# Altium schematic sheet Sheet1.SchDoc
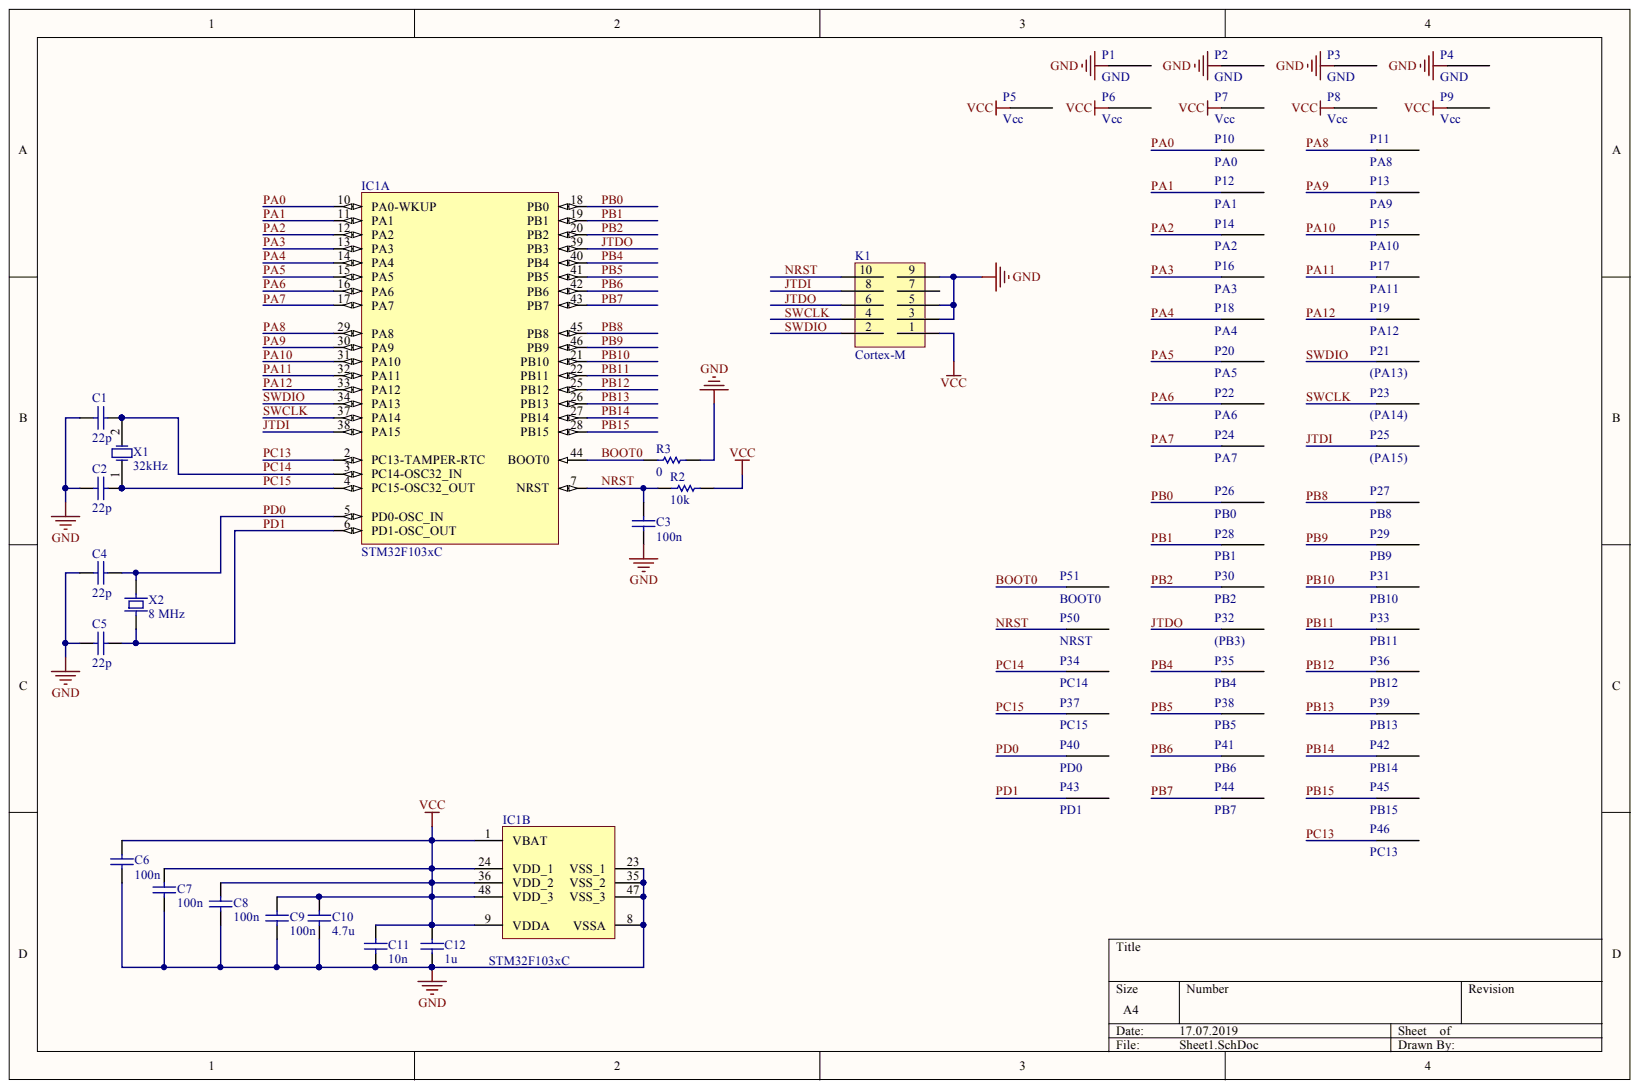
[diagram p0: Sheet1.SchDoc]

## Parts
C1  22p  [CAPC2013X88X50NL20T25]
C2  22p  [CAPC2013X88X50NL20T25]
C3  100n  [CAPC2013X88X50NL20T25]
C4  22p  [CAPC2013X88X50NL20T25]
C5  22p  [CAPC2013X88X50NL20T25]
C6  100n  [CAPC2013X88X50NL20T25]
C7  100n  [CAPC2013X88X50NL20T25]
C8  100n  [CAPC2013X88X50NL20T25]
C9  100n  [CAPC2013X88X50NL20T25]
C10  4.7u  [CAPC2013X88X50NL20T25]
C11  10n  [CAPC2013X88X50NL20T25]
C12  1u  [CAPC2013X88X50NL20T25]
IC1  STM32F103xC  [STM-LQFP48_N]  (parts A,B)
K1  Cortex-M  [PCB-l6fbhhbmmdzifrvjozvs-1]
P1  GND  [PIN-089]
P2  GND  [PIN-089]
P3  GND  [PIN-089]
P4  GND  [PIN-089]
P5  Vcc  [PIN-089]
P6  Vcc  [PIN-089]
P7  Vcc  [PIN-089]
P8  Vcc  [PIN-089]
P9  Vcc  [PIN-089]
P10  PA0  [PIN-089]
P11  PA8  [PIN-089]
P12  PA1  [PIN-089]
P13  PA9  [PIN-089]
P14  PA2  [PIN-089]
P15  PA10  [PIN-089]
P16  PA3  [PIN-089]
P17  PA11  [PIN-089]
P18  PA4  [PIN-089]
P19  PA12  [PIN-089]
P20  PA5  [PIN-089]
P21  (PA13)  [PIN-089]
P22  PA6  [PIN-089]
P23  (PA14)  [PIN-089]
P24  PA7  [PIN-089]
P25  (PA15)  [PIN-089]
P26  PB0  [PIN-089]
P27  PB8  [PIN-089]
P28  PB1  [PIN-089]
P29  PB9  [PIN-089]
P30  PB2  [PIN-089]
P31  PB10  [PIN-089]
P32  (PB3)  [PIN-089]
P33  PB11  [PIN-089]
P34  PC14  [PIN-089]
P35  PB4  [PIN-089]
P36  PB12  [PIN-089]
P37  PC15  [PIN-089]
P38  PB5  [PIN-089]
P39  PB13  [PIN-089]
P40  PD0  [PIN-089]
P41  PB6  [PIN-089]
P42  PB14  [PIN-089]
P43  PD1  [PIN-089]
P44  PB7  [PIN-089]
P45  PB15  [PIN-089]
P46  PC13  [PIN-089]
P50  NRST  [PIN-089]
P51  BOOT0  [PIN-089]
R2  10k  [RESC2012X07N]
R3  0  [RESC2012X07N]
X1  32kHz  [PCB-9zwnom3j82v50zoz89x1-1]
X2  8 MHz  [9B-8.000MEEJ-B]

## Nets
GND: C1.1 C2.1 C3.2 C4.1 C5.1 C6.2 C7.2 C8.2 C9.2 C10.2 C11.2 C12.2 IC1.8(VSSA) IC1.23(VSS_1) IC1.35(VSS_2) IC1.47(VSS_3) K1.3 K1.5 K1.9 P1.1 P2.1 P3.1 P4.1 R3.1
VCC: C6.1 C7.1 C8.1 C9.1 C10.1 C11.1 C12.1 IC1.1(VBAT) IC1.9(VDDA) IC1.24(VDD_1) IC1.36(VDD_2) IC1.48(VDD_3) K1.1 P5.1 P6.1 P7.1 P8.1 P9.1 R2.1
BOOT0: IC1.44(BOOT0) P51.1 R3.2
JTDI: IC1.38(PA15) K1.8 P25.1
JTDO: IC1.39(PB3) K1.6 P32.1
NRST: C3.1 IC1.7(NRST) K1.10 P50.1 R2.2
PA0: IC1.10(PA0-WKUP) P10.1
PA1: IC1.11(PA1) P12.1
PA2: IC1.12(PA2) P14.1
PA3: IC1.13(PA3) P16.1
PA4: IC1.14(PA4) P18.1
PA5: IC1.15(PA5) P20.1
PA6: IC1.16(PA6) P22.1
PA7: IC1.17(PA7) P24.1
PA8: IC1.29(PA8) P11.1
PA9: IC1.30(PA9) P13.1
PA10: IC1.31(PA10) P15.1
PA11: IC1.32(PA11) P17.1
PA12: IC1.33(PA12) P19.1
PB0: IC1.18(PB0) P26.1
PB1: IC1.19(PB1) P28.1
PB2: IC1.20(PB2) P30.1
PB4: IC1.40(PB4) P35.1
PB5: IC1.41(PB5) P38.1
PB6: IC1.42(PB6) P41.1
PB7: IC1.43(PB7) P44.1
PB8: IC1.45(PB8) P27.1
PB9: IC1.46(PB9) P29.1
PB10: IC1.21(PB10) P31.1
PB11: IC1.22(PB11) P33.1
PB12: IC1.25(PB12) P36.1
PB13: IC1.26(PB13) P39.1
PB14: IC1.27(PB14) P42.1
PB15: IC1.28(PB15) P45.1
PC13: IC1.2(PC13-TAMPER-RTC) P46.1
PC14: C1.2 IC1.3(PC14-OSC32_IN) P34.1 X1.2
PC15: C2.2 IC1.4(PC15-OSC32_OUT) P37.1 X1.1
PD0: C4.2 IC1.5(PD0-OSC_IN) P40.1 X2.2
PD1: C5.2 IC1.6(PD1-OSC_OUT) P43.1 X2.1
SWCLK: IC1.37(PA14) K1.4 P23.1
SWDIO: IC1.34(PA13) K1.2 P21.1

Not connected: K1 7

## Library data of the parts
C1-C12 = CMP-3913301-2: CAPACITOR, 0805, C0G, 50V, 2.2NF; Capacitance: 2.2e-09 F; Capacitance Tolerance: ±5%; Dielectric Characteristic: C0G/NP0; Dielectric Material: Ceramic; Lead-Free Status: Lead Free; Voltage Rating (DC): 50.0 V; Case/Package: 0805; Component Kind: Standard; Library Name: CommunityVault; Mounting Style: Surface Mount; OctopartID: bb4973a362c9648e; Octopart Url: https://octopart.com/c0805c222j5gacauto-kemet-31522022; Packaging: Cut Tape (CT); REACH SVHC Compliance: No SVHC; RoHS: Compliant
IC1 = STM32F103C8T6TR: ARM Cortex-M3 32-bit MCU, 64 KB Flash, 20 KB Internal RAM, 37 I/Os, 48-pin LQFP, -40 to 85 degC, Tape and Reel; Lead-Free Status: Lead Free; Component Kind: Standard; Library Name: Octopart library; Lifecycle Status: Active; OctopartID: c3c10a7d8bb51c06; Octopart Url: https://octopart.com/stm32f103c8t6tr-stmicroelectronics-11955474; RoHS: Compliant
K1 = WSL10-4: Wannensteckleiste 10 pin; CustomComponentID: 4svy7yiuz1vlprmntkh4; Component Kind: Standard; Library Name: FavoritesLibrary
P1-P46 P50 P51 = PIN-7: One-pin connector; CustomComponentID: iv9w4hav5xulhqaz2xo1; Component Kind: Standard; Library Name: FavoritesLibrary
R2 R3 = CMP-1041dbfef0d0cf86-1: Res, Thin Film, 7k87, 0.1%, 0.25w, 0805; Manufacturer Part Number: RP73PF2A7K87BTDF; Power Rating: 250 mW; Resistance: 7.87 kΩ; Voltage Rating (DC): 150 V; Case/Package: 0805, 2012; Component Kind: Standard; Library Name: CommunityVault; Lifecycle Status: Not Listed by Manufacturer; Mounting Style: Surface Mount; OctopartID: 1041dbfef0d0cf86; Octopart URL: http://octopart.com/rp73pf2a7k87btdf-te+connectivity-45467127; Packaging: Cut Tape (CT); REACH SVHC Compliance: No SVHC; Resistance Tolerance: ±0.1%; RoHS: Compliant
X1 = AB38T-32.768KHZ: AB38T Series 32.768 kHz ±20 ppm 12.5 pF -10 to +60 °C Tuning Fork Crystal; Equivalent Series Resistance (ESR): 30.0 kΩ; Frequency: 32.8 kHz; Lead-Free Status: Lead Free; Load Capacitance: 12.5 pF; Component Kind: Standard; Library Name: Octopart library; Mounting Style: Through Hole; Number of Pins: 2; OctopartID: 1ccad5c4e9f7c30d; Octopart URL: http://octopart.com/ab38t-32.768khz-abracon-315483; Packaging: Bulk; REACH SVHC Compliance: No SVHC; Size-Height: 8.30 mm
X2 = 9B-8.000MEEJ-B: Crystal 8MHz +/-10ppm (Tol) +/-20ppm (Stability) 18pF FUND 80Ohm 2-Pin DIP HC-49/S Thru-Hole Bulk; Load Capacitance: 18.0 pF; Component Kind: Standard; Library Name: Octopart library; OctopartID: 9de955fd51fc422e; REACH SVHC Compliance: No SVHC
